# Altium schematic sheet DEVICE2.SchDoc(DEVICE2)
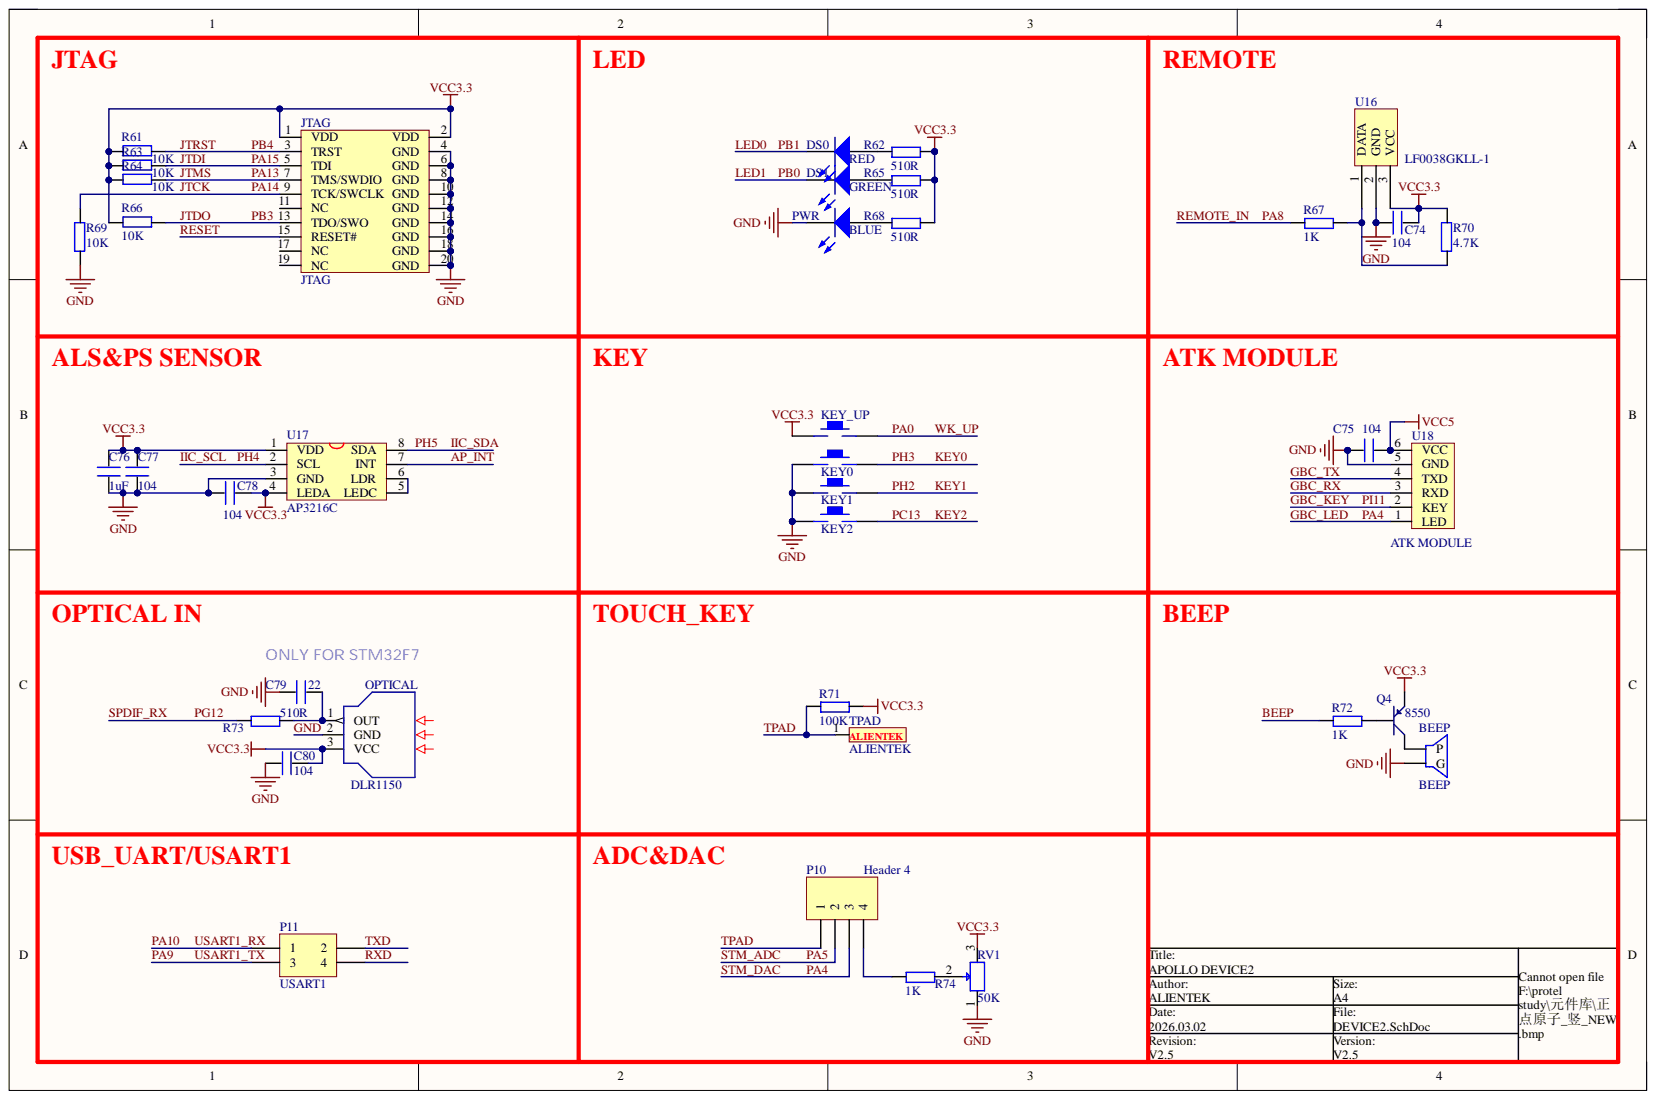
[diagram p0: DEVICE2.SchDoc]

## Parts
BEEP  BEEP  [BELL-5]
C74  104  [0402]
C75  104  [0402]
C76  1uF  [0402]
C77  104  [0402]
C78  104  [0402]
C79  22  [0402]
C80  104  [0402]
DS0  RED  [SMDLED]
DS1  GREEN  [SMDLED]
JTAG  JTAG  [JTAG_20]
KEY0  KEY_M  [KEY_M]  (value not drawn)
KEY1  KEY_M  [KEY_M]  (value not drawn)
KEY2  KEY_M  [KEY_M]  (value not drawn)
KEY_UP  KEY_M  [KEY_M]  (value not drawn)
OPTICAL  DLR1150  [DLR-1150 / 2150]
P10  Header 4  [HDR1X4]
P11  USART1  [HDR2X2]
PWR  BLUE  [SMDLED]
Q4  8550  [SOT-23R]
R61  10K  [0402]
R62  510R  [0402]
R63  10K  [0402]
R64  10K  [0402]
R65  510R  [0402]
R66  10K  [0402]
R67  1K  [0402]
R68  510R  [0402]
R69  10K  [0402]
R70  4.7K  [0402]
R71  100K  [0402]
R72  1K  [0402]
R73  510R  [0402]
R74  1K  [0402]
RV1  50K  [RV]
TPAD  ALIENTEK  [ATK_TPAD]
U16  LF0038GKLL-1  [IR-LF0038GKLL-1]
U17  AP3216C  [QFN8]
U18  ATK MODULE  [HEAD6]

## Nets
VCC3.3: C74.1 C76.1 C77.1 C78.2 C80.1 JTAG.1(VDD) JTAG.2(VDD) KEY_UP.1 OPTICAL.3 Q4.1 R61.2 R62.2 R63.2 R64.2 R65.2 R66.2 R68.2 R70.1 R71.2 RV1.3 U16.3 U17.1(VDD) U17.4(LEDA)
VCC5: C75.1 U18.6(VCC)
AP_INT: U17.7(INT)
BEEP: R72.1
GBC_KEY: U18.2(KEY)
GBC_LED: P10.3 U18.1(LED)
GBC_RX: U18.3(RXD)
GBC_TX: U18.4(TXD)
GND: BEEP.2 C74.2 C75.2 C76.2 C77.2 C78.1 C79.2 C80.2 JTAG.4(GND) JTAG.6(GND) JTAG.8(GND) JTAG.10(GND) JTAG.12(GND) JTAG.14(GND) JTAG.16(GND) JTAG.18(GND) JTAG.20(GND) KEY0.1 KEY1.1 KEY2.1 OPTICAL.2 PWR.2 R69.2 RV1.1 U16.2 U17.3(GND) U18.5(GND)
IIC_SCL: U17.2(SCL)
IIC_SDA: U17.8(SDA)
JTCK: JTAG.9(TCK/SWCLK) R69.1
JTDI: JTAG.5(TDI) R63.1
JTDO: JTAG.13(TDO/SWO) R66.1
JTMS: JTAG.7(TMS/SWDIO) R64.1
JTRST: JTAG.3(TRST) R61.1
KEY0: KEY0.2
KEY1: KEY1.2
KEY2: KEY2.2
LED0: DS0.2
LED1: DS1.2
PA0: KEY_UP.2
PA5: P10.2
PA8: R67.1
PA9: P11.3
PA10: P11.1
PG12: R73.1
RESET: JTAG.15(RESET#)
RXD: P11.4
TPAD: P10.1 R71.1 TPAD.1
TXD: P11.2
NetBEEP_1: BEEP.1 Q4.3
NetC79_1: C79.1 OPTICAL.1 R73.2
NetDS0_1: DS0.1 R62.1
NetDS1_1: DS1.1 R65.1
NetP10_4: P10.4 R74.1
NetPWR_1: PWR.1 R68.1
NetQ4_2: Q4.2 R72.2
NetR67_2: R67.2 R70.2 U16.1
NetR74_2: R74.2 RV1.2
NetU17_5: U17.5(LEDC) U17.6(LDR)

Not connected: JTAG 11(NC) 17(NC) 19(NC)

## Library data of the parts
C74-C80 = C: Component Kind: Standard; Library Name: MYCOMLIB.IntLib
DS0 DS1 PWR = LED2: Typical RED, GREEN, YELLOW, AMBER GaAs LED; Component Kind: Standard; LatestRevisionDate: 17-Jul-2002; LatestRevisionNote: Re-released for DXP Platform.; Library Name: Miscellaneous Devices.IntLib; PackageDocument: Feb-2002; PackageReference: 3.2X1.6X1.1; Published: 8-Jun-2000; Simulation: LED2; Publisher: Altium Limited
OPTICAL = DLR 1150/2150: 音频光纤接收座; Component Kind: Standard; Library Name: ALIENTEK_COMPONENT.IntLib
P10 = Header 4: Header, 4-Pin; Component Kind: Standard; LatestRevisionDate: 17-Jul-2002; LatestRevisionNote: Re-released for DXP Platform.; Library Name: Miscellaneous Connectors.IntLib; Publisher: Altium Limited
P11 = Header 2X2: Header, 2-Pin, Dual row; Component Kind: Standard; LatestRevisionDate: 17-Jul-2002; LatestRevisionNote: Re-released for DXP Platform.; Library Name: Miscellaneous Connectors.IntLib; Publisher: Altium Limited
Q4 = PNP: 8550/BCW68; Component Kind: Standard; Library Name: ALIENTEK_COMPONENT.IntLib
R61-R74 = R: Component Kind: Standard; Library Name: MYCOMLIB.IntLib
RV1 = RV: Component Kind: Standard; Library Name: ALIENTEK_COMPONENT.IntLib
U16 = HS0038: Component Kind: Standard; Library Name: selfmade.SchLib
U17 = AP3216C: ALS+PS Sensor; Component Kind: Standard; Library Name: ALIENTEK_COMPONENT.IntLib
U18 = ATK-HC05: Component Kind: Standard; Description: ATK-HC05; Library Name: ALIENTEK_COMPONENT.IntLib
BEEP = BEEP: Component Kind: Standard; Library Name: ALIENTEK_COMPONENT.IntLib
JTAG = JTAG: Component Kind: Standard; Library Name: MYCOMLIB.IntLib
KEY0-KEY2 KEY_UP = KEY_M: Component Kind: Standard; Library Name: ALIENTEK_COMPONENT.IntLib
TPAD = ALIENTEK: Component Kind: Standard; Library Name: WARSHIP_STM32_V4.3.SCHLIB
